# Altium schematic sheet connectors.SchDoc
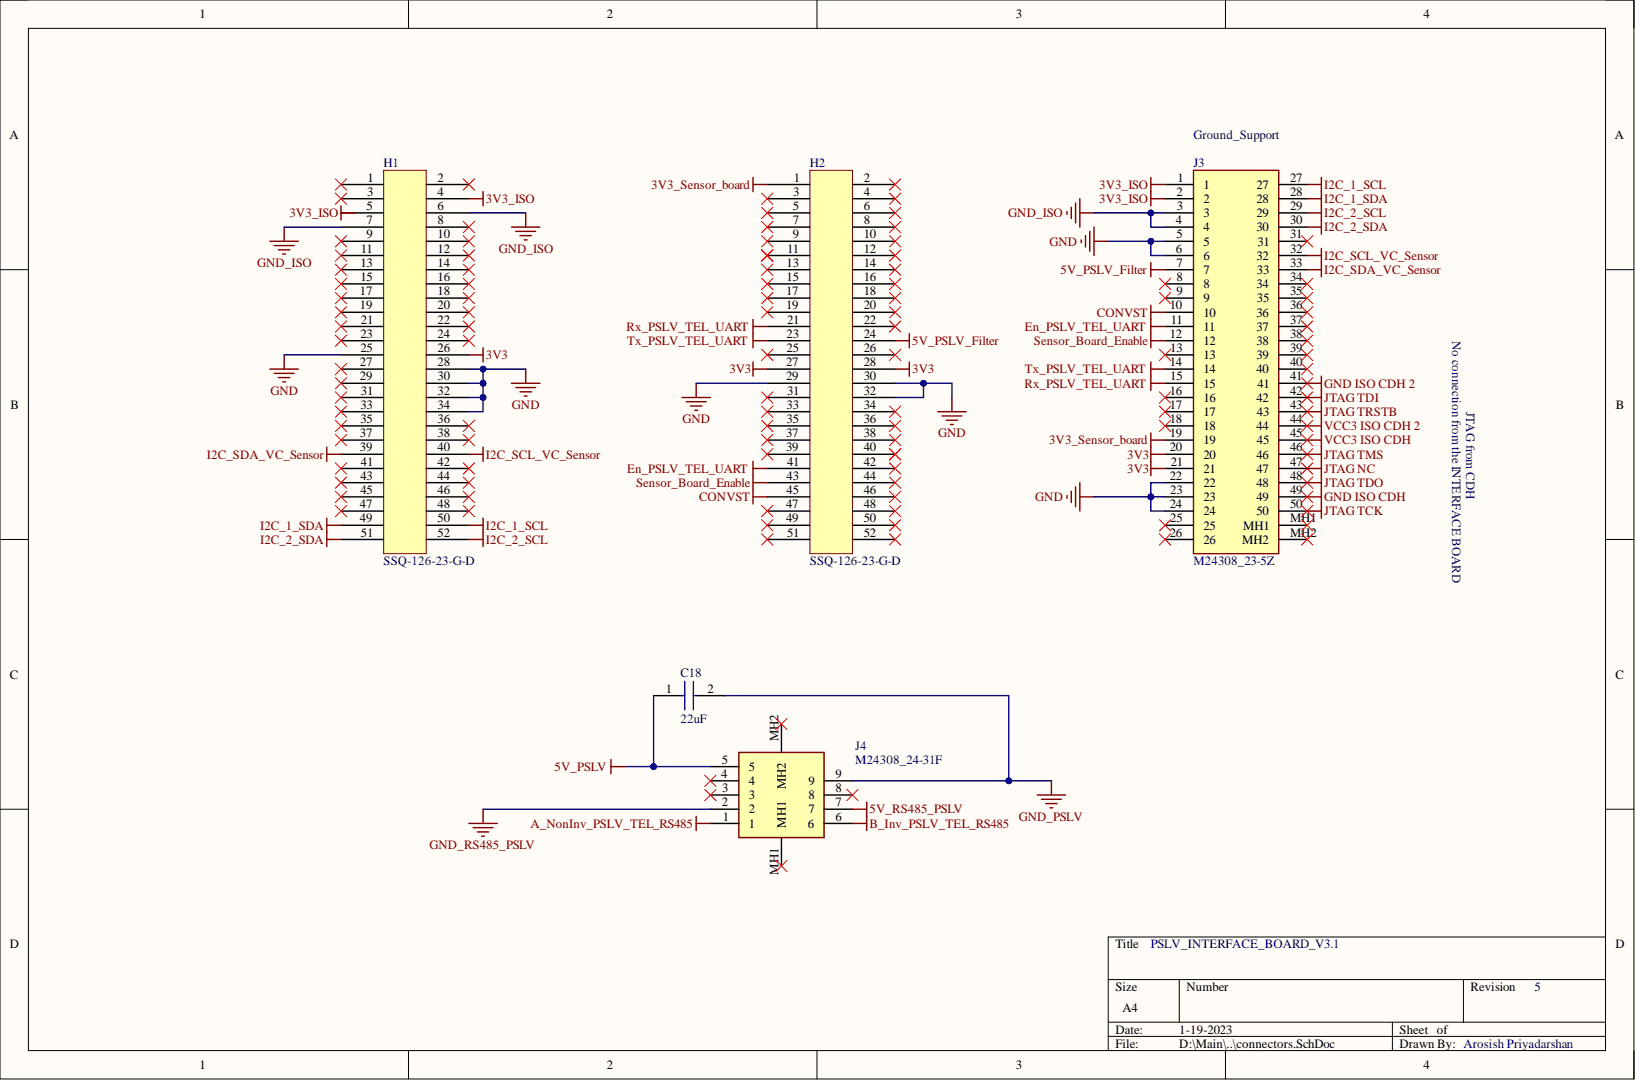
[diagram p0: connectors.SchDoc]

## Parts
C18  22uF  [C1206]
H1  SSQ-126-23-G-D  [SAMTEC_SSQ-126-23-G]
H2  SSQ-126-23-G-D  [SAMTEC_SSQ-126-23-G]
J3  M24308_23-5Z  [M24308235Z]
J4  M24308_24-31F  [M243082431F]

## Nets
3V3: H1.26 H2.27 H2.28 J3.20 J3.21
3V3_ISO: H1.4 J3.1 J3.2
3V3_Sensor_board: H2.1 J3.19
5V_PSLV: C18.1 J4.5
5V_PSLV_Filter: H2.24 J3.7
5V_RS485_PSLV: J4.7
A_NonInv_PSLV_TEL_RS485: J4.1
B_Inv_PSLV_TEL_RS485: J4.6
CONVST: H2.45 J3.10
En_PSLV_TEL_UART: H2.41 J3.11
GND: H1.25 H1.28 H1.30 H1.32 H1.34 H2.29 H2.30 H2.32 J3.5 J3.6 J3.22 J3.23 J3.24
GND ISO CDH: J3.49
GND ISO CDH 2: J3.41
GND_ISO: H1.6 H1.7 J3.3 J3.4
GND_PSLV: C18.2 J4.9
GND_RS485_PSLV: J4.2
I2C_1_SCL: H1.50 J3.27
I2C_1_SDA: H1.49 J3.28
I2C_2_SCL: H1.52 J3.29
I2C_2_SDA: H1.51 J3.30
I2C_SCL_VC_Sensor: H1.40 J3.32
I2C_SDA_VC_Sensor: H1.39 J3.33
JTAG NC: J3.47
JTAG TCK: J3.50
JTAG TDI: J3.42
JTAG TDO: J3.48
JTAG TMS: J3.46
JTAG TRSTB: J3.43
Rx_PSLV_TEL_UART: H2.21 J3.15
Sensor_Board_Enable: H2.43 J3.12
Tx_PSLV_TEL_UART: H2.23 J3.14
VCC3 ISO CDH: J3.45
VCC3 ISO CDH 2: J3.44

Not connected: H1 1 2 3 5 8 9 10 11 12 13 14 15 16 17 18 19 20 21 22 23 24 27 29 31 33 35 36 37 38 41 42 43 44 45 46 47 48; H2 2 3 4 5 6 7 8 9 10 11 12 13 14 15 16 17 18 19 20 22 25 26 31 33 34 35 36 37 38 39 40 42 44 46 47 48 49 50 51 52; J3 8 9 13 16 17 18 25 26 31 34 35 36 37 38 39 40 MH1 MH2; J4 3 4 8 MH1 MH2

## Library data of the parts
C18 = C1206C226K8RAC7210: Capacitor; Manufacturer_Name: KEMET; Component Kind: Standard; Datasheet Link: https://content.kemet.com/datasheets/KEM_C1002_X7R_SMD.pdf; Library Name: Schlib1.SchLib; Manufacturer_Part_Number: C1206C226K8RAC7210; Mouser Part Number: 80-C1206C226K8R7210; Mouser Price/Stock: https://www.mouser.co.uk/ProductDetail/KEMET/C1206C226K8RAC7210?qs=rrS6PyfT74d338OvQfUspw%3D%3D
H1 H2 = SSQ-126-23-G-D: CONN RCPT 52POS 0.1 GOLD PCB; Manufacturer: Samtec; Contact Resistance: 10mR; Gender: Female; Max Current Rating: 7.6A; Max Voltage Rating (AC): 550V; Number of Contacts: 52; Pitch: 2.54mm; Component Kind: Standard; Contact Material: Bronze; Contact Plating: Gold; Depth: 4.95mm; Housing Color: Black; Housing Material: Polymer; Length: 66.55mm; Library Name: Schlib1.SchLib; Manufacturer Part Number: SSQ-126-23-G-D; Max Operating Temperature: 125°C; Min Operating Temperature: -55°C; Number of Rows: 2; Orientation: Straight; Pricing 1: 1 = 7.74000, 10 = 7.16000, 100 = 5.99850, 500 = 5.03100, 1000 = 4.83750 (USD); Radiation Hardening: No; RoHS: Yes; Stock 1: 113; Supplier 1: Digi-Key; Supplier Part Number 1: SAM11927-ND; Termination: Solder
J3 = M24308_23-5Z: Connector; Manufacturer_Name: Amphenol; Manufacturer_Part_Number: M24308/23-5Z; Component Kind: Standard; Datasheet Link: https://eu.mouser.com/datasheet/2/18/1/DSUB_2018-1651582.pdf; Height: 13.91mm; Library Name: Schlib1.SchLib; Mouser Part Number: 523-M24308235Z; Mouser Price/Stock: https://www.mouser.co.uk/ProductDetail/Amphenol-Pcd/M24308-23-5Z?qs=Sn4Bov5EoyAswT47etBOqw%3D%3D
J4 = M24308_24-31F: Connector; Manufacturer_Name: TE Connectivity; Manufacturer_Part_Number: M24308/24-31F; Component Kind: Standard; Datasheet Link: https://www.te.com/commerce/DocumentDelivery/DDEController?Action=showdoc&DocId=Customer+Drawing%7F1218441%7FC%7Fpdf%7FEnglish%7FENG_CD_1218441_C.pdf%7F1218441-1; Height: 12.93mm; Library Name: Schlib1.SchLib; Mouser Part Number: 571-M24308/24-31F; Mouser Price/Stock: https://www.mouser.co.uk/ProductDetail/TE-Connectivity-Raychem/M24308-24-31F?qs=DgToa77CwMqMsoylgOHpyw%3D%3D
